# Altium schematic sheet first_1.SchDoc(first_1)
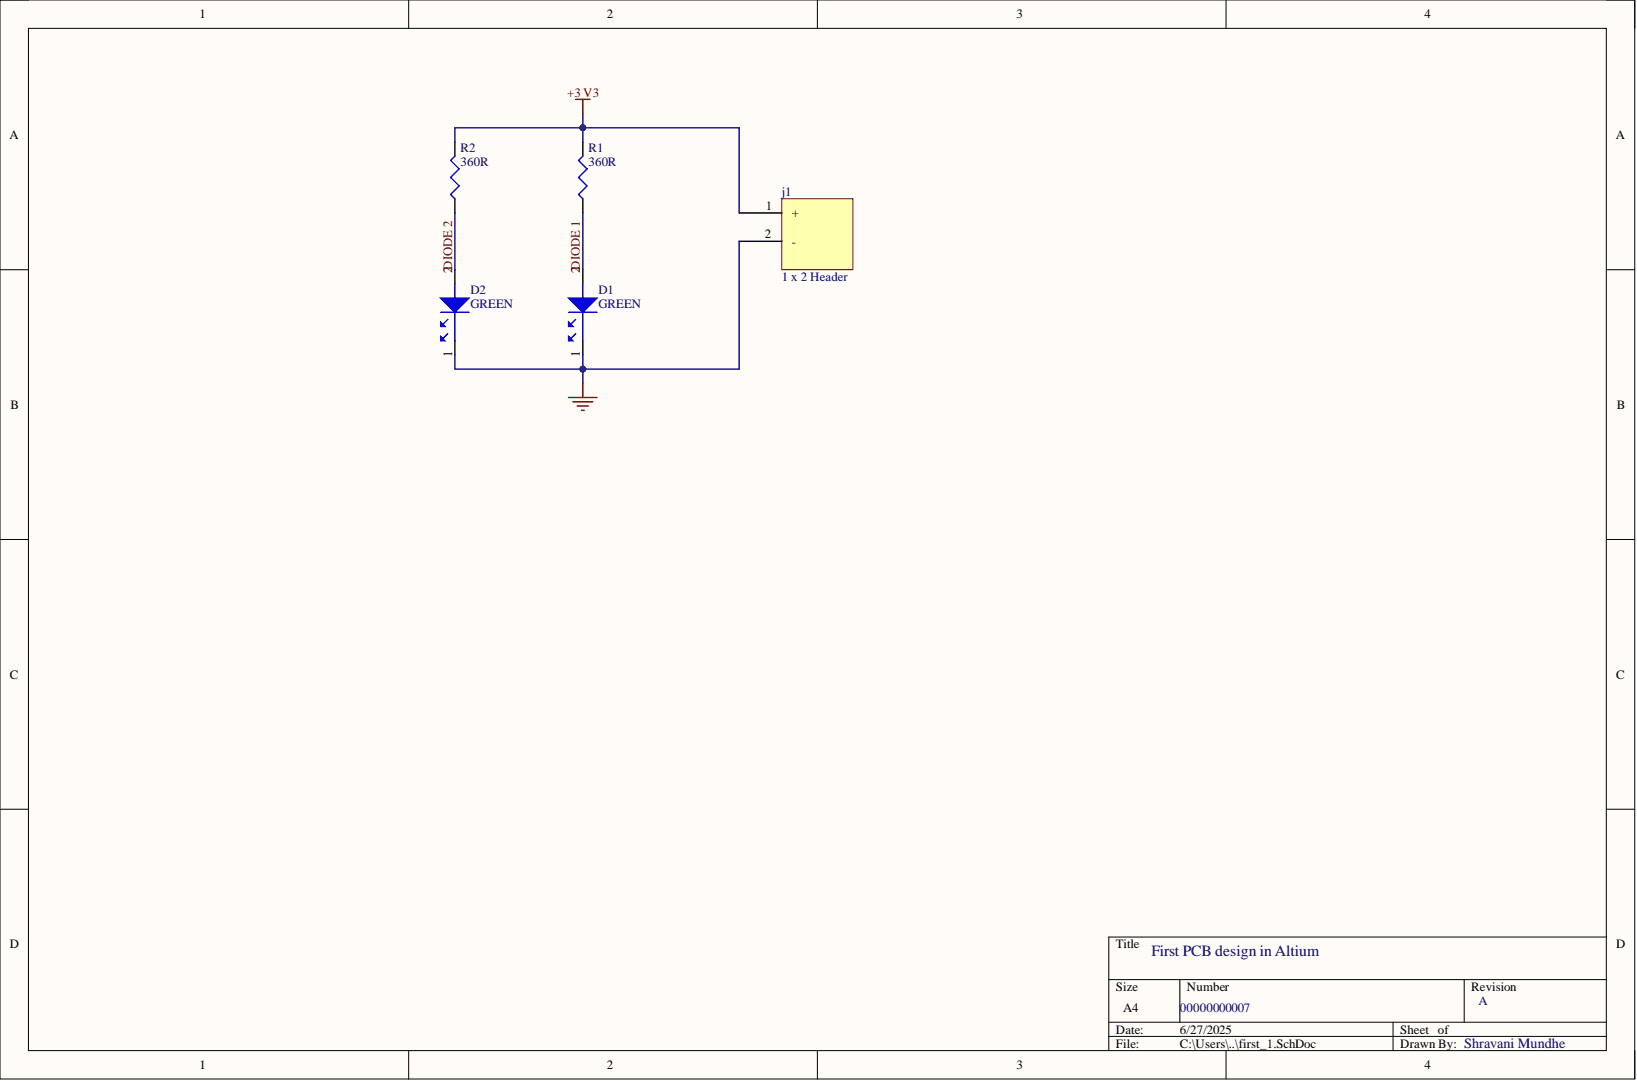
[diagram p0: first_1.SchDoc]

## Parts
D1  GREEN  [LED0603G]
D2  GREEN  [LED0603G]
j1  1 x 2 Header  [HDR1X2]
R1  360R  [R0805]
R2  360R  [R0805]

## Nets
+3 V3: j1.1 R1.2 R2.2
GND: D1.1 D2.1 j1.2
DIODE 1: D1.2 R1.1
DIODE 2: D2.2 R2.1

## Library data of the parts
D1 D2 = LSM0603453V: LED GREEN CLEAR CHIP 0603 SMD; Manufacturer: Visual Communications; Optoelectronic Device Type: SINGLE COLOR LED; Category: LEDs; Component Kind: Standard; ComponentLink1Description: https://datasheet.ciiva.com:443/pdfs/VipMasterIC/IC/VISU/VISU-S-A0009188582/VISU-S-A0009188582-1.pdf?src-supplier=IHS+Markit; ComponentLink1URL: https://datasheet.ciiva.com:443/pdfs/VipMasterIC/IC/VISU/VISU-S-A0009188582/VISU-S-A0009188582-1.pdf?src-supplier=IHS+Markit; ComponentLink2Description: http://www.farnell.com/datasheets/2699708.pdf?src-supplier=Farnell; ComponentLink2URL: http://www.farnell.com/datasheets/2699708.pdf?src-supplier=Farnell; ComponentLink3Description: http://www.farnell.com/datasheets/2699708.pdf?src-supplier=Newark; ComponentLink3URL: http://www.farnell.com/datasheets/2699708.pdf?src-supplier=Newark; ComponentLink4Description: https://vcclite.com/wp-content/uploads/wpallimport/files/files/LSM0603453V.pdf?src-supplier=Digikey; ComponentLink4URL: https://vcclite.com/wp-content/uploads/wpallimport/files/files/LSM0603453V.pdf?src-supplier=Digikey; Library Name: LED_Schlib1.SchLib; Manufacturer Part Number: LSM0603453V; Pricing: 1=0.5, 10=0.291, 100=0.1218, 500=0.1086, 1000=0.1071, 4000=0.0922, 8000=0.08991 (USD); Reach SVHC Compliant: Yes; RoHS: Yes; RoHS Compliant: Yes; Stock: 23373; Supplier: Digikey; Supplier Part Number: LSM0603453VCT-ND
j1 = 22-27-2021 (3): Connector Header Through Hole 2 position 0.100" (2.54mm); Manufacturer: Molex; Body Breadth: 0.23inch; Body Depth: 0.46inch; Body Length: 0.2inch; Body/Shell Style: RECEPTACLE; Connector Type: BOARD CONNECTOR; Contact Pattern: RECTANGULAR; Contact Resistance: 10mR; Contact Style: SQ PIN-SKT; DIN Conformance: No; Durability: 25Cycles; Insulation Resistance: 1GR; Mating Contact Pitch: 0.1inch; Mating Information: MULTIPLE MATING PARTS AVAILABLE; Mounting Option 1: LOCKING; Number Of Connectors: 1; Number of Contacts: 2; Option: General Purpose; Plating Thickness: 100uin; Polarization Key: POLARIZED HOUSING; Rated Current (Signal): 4A; Reference Standard: UL; Reliability: COMMERCIAL; Category: Headers and Wire Housings; Component Kind: Standard; ComponentLink1Description: https://datasheet.ciiva.com:443/pdfs/VipMasterIC/IC/MOLE/MOLE-E-A0003552261/MOLE-S-A0003534498-1.pdf?src-supplier=IHS+Markit; ComponentLink1URL: https://datasheet.ciiva.com:443/pdfs/VipMasterIC/IC/MOLE/MOLE-E-A0003552261/MOLE-S-A0003534498-1.pdf?src-supplier=IHS+Markit; ComponentLink2Description: https://datasheet.ciiva.com/15217/getdatasheetpartid-163454-15217683.pdf?src-supplier=Verical; ComponentLink2URL: https://datasheet.ciiva.com/15217/getdatasheetpartid-163454-15217683.pdf?src-supplier=Verical; ComponentLink3Description: https://datasheet.ciiva.com/9468/0022272021-pcb-headers-9468403.pdf?src-supplier=Avnet; ComponentLink3URL: https://datasheet.ciiva.com/9468/0022272021-pcb-headers-9468403.pdf?src-supplier=Avnet; Contact Finish - Mating: NOT SPECIFIED; Contact Finish - Termination: Bright Tin (Sn); Contact Gender: Male; Contact Material: Brass; Filter Feature: No; Flammability Rating: UL94 V-0; IEC Conformance: No; Insulation Color: White; Insulation Material: Nylon; JESD-609 Code: e3; Library Name: LED_Schlib1.SchLib; Manufacturer Part Number: 22-27-2021; Max Operating Temperature: 75°C; MIL Conformance: No; Min Operating Temperature: 0°C; Mixed Contacts: No; Mounting Style: STRAIGHT; Mounting Technology: Board; Number Of PCB Rows: 1; Number of Rows Loaded: 1; PCB Contact Pattern: RECTANGULAR; Pricing: 1=0.24, 10=0.208, 100=0.1766, 500=0.15764, 2850=0.1394, 5700=0.13275, 11400=0.12641 (USD); Reach SVHC Compliant: Yes; RoHS: Yes; RoHS Compliant: Yes; Stock: 8519; Supplier: Digikey; Supplier Part Number: WM4111-ND; Terminal Length: 0.14inch; Terminal Pitch: 2.54mm; Termination: Solder
R1 R2 = AC0805FR-07360RL: RES SMD 360 OHM 1% 1/8W 0805; Manufacturer: Yageo; Additional Feature: LASER TRIMMABLE; Package Height: 0.5mm; Package Length: 2mm; Package Width: 1.25mm; Power: 125mW; Rated Temperature: 70°C; Reference Standard: AEC-Q200; Temperature Coefficient (ppm/Cel): 100; Working Voltage: 150V; Case/Package: 0805; Category: Chip SMD Resistors; Component Kind: Standard; ComponentLink1Description: https://datasheet.ciiva.com:443/pdfs/VipMasterIC/IC/YAGO/YAGO-S-A0003597364/YAGO-S-A0003597364-1.pdf?src-supplier=IHS+Markit; ComponentLink1URL: https://datasheet.ciiva.com:443/pdfs/VipMasterIC/IC/YAGO/YAGO-S-A0003597364/YAGO-S-A0003597364-1.pdf?src-supplier=IHS+Markit; ComponentLink2Description: https://datasheet.octopart.com/AC0805FR-07360RL-Yageo-datasheet-178509465.pdf?src-supplier=Newark; ComponentLink2URL: https://datasheet.octopart.com/AC0805FR-07360RL-Yageo-datasheet-178509465.pdf?src-supplier=Newark; ComponentLink3Description: http://www.yageo.com/NewPortal/yageodocoutput?fileName=/pdf/R-Chip/PYu-AC_51_RoHS_L_6.pdf&src-supplier=Digikey; ComponentLink3URL: http://www.yageo.com/NewPortal/yageodocoutput?fileName=/pdf/R-Chip/PYu-AC_51_RoHS_L_6.pdf&src-supplier=Digikey; Construction: Chip; JESD-609 Code: e3; Library Name: LED_Schlib1.SchLib; Manufacturer Part Number: AC0805FR-07360RL; Max Operating Temperature: 155°C; Min Operating Temperature: -55°C; Mounting Technology: Surface Mount; Package Shape: Rectangular; Packaging: Tape and Reel; Pins: 2; Pricing: 1=0.1, 10=0.016, 100=0.0133, 1000=0.01224, 5000=0.0102, 10000=0.00714, 15000=0.00663, 25000=0.00612, 35000=0.0051, 50000=0.00408 (USD); Reach SVHC Compliant: Yes; Resistor Type: FIXED RESISTOR; RoHS: Yes; RoHS Compliant: Yes; Stock: 500; Supplier: Digikey; Supplier Part Number: 13-AC0805FR-07360RLCT-ND; Technology: METAL GLAZE/THICK FILM; Terminal Finish: Nickel; Termination: Wraparound; Tolerance: 1%; Value: 360R
